# Altium schematic sheet Inverter_Breakout.SchDoc
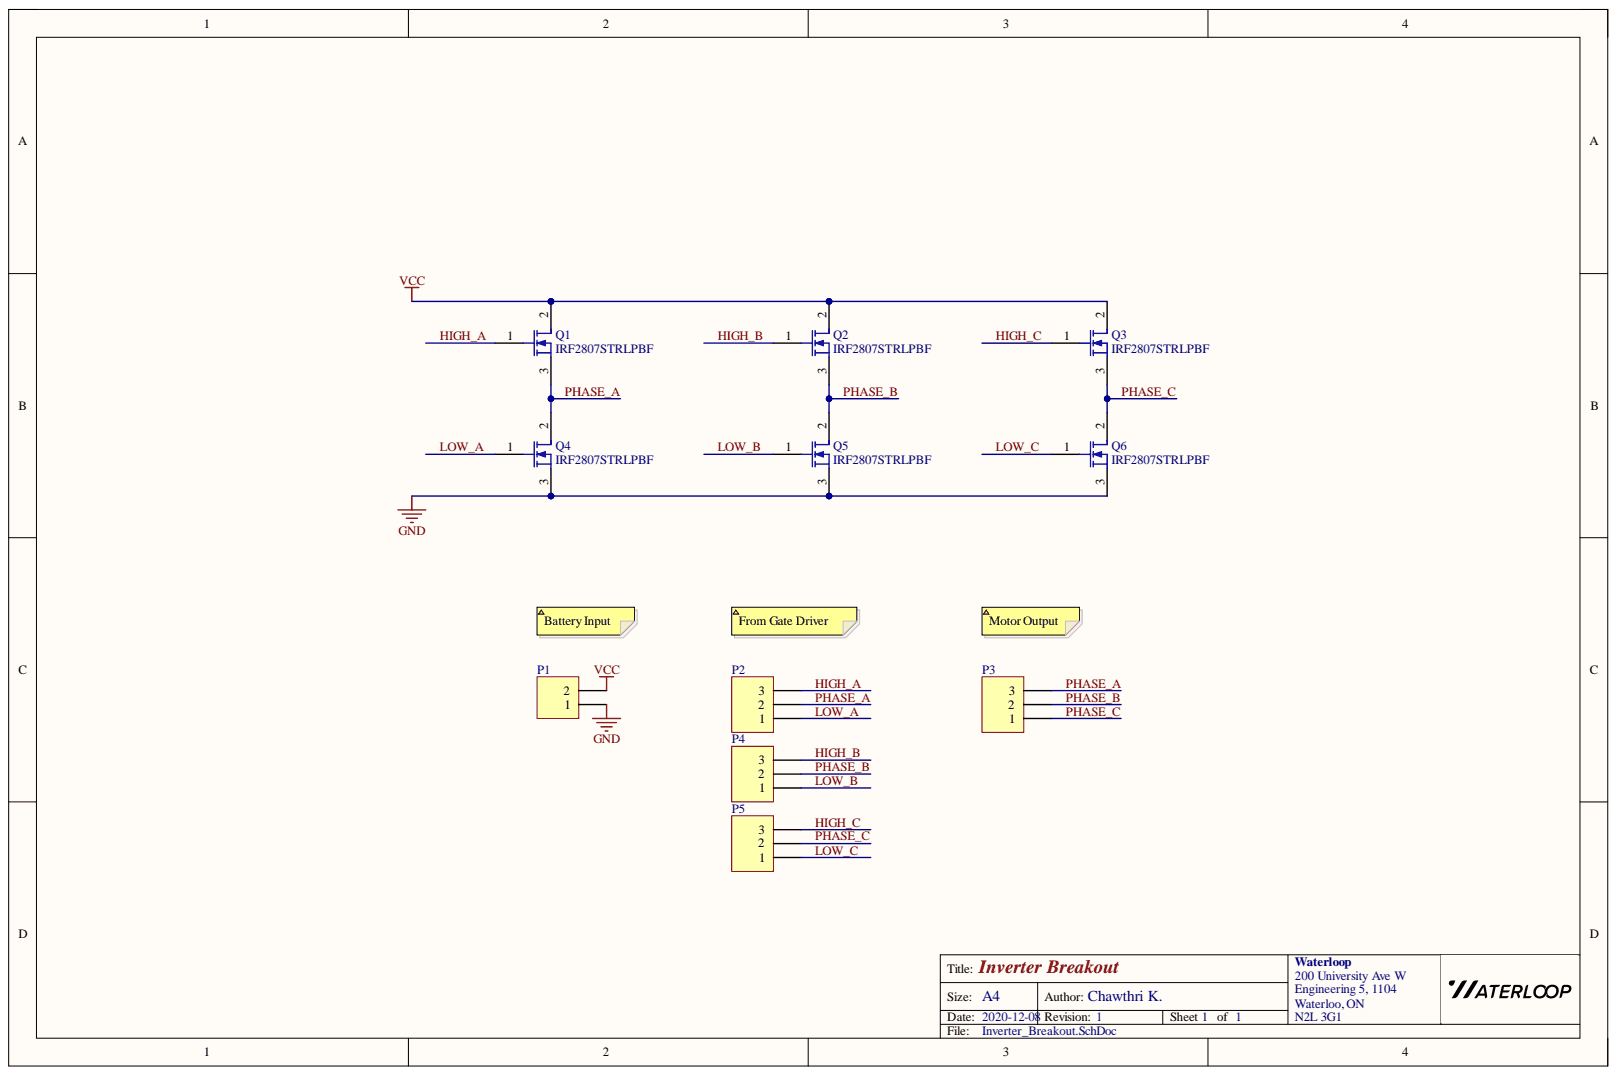
[diagram p0: Inverter_Breakout.SchDoc]

## Parts
P1  1x2 Header  [HDR1X2]  (value not drawn)
P2  1x3 Header  [HDR1X3]  (value not drawn)
P3  1x3 Header  [HDR1X3]  (value not drawn)
P4  1x3 Header  [HDR1X3]  (value not drawn)
P5  1x3 Header  [HDR1X3]  (value not drawn)
Q1  IRF2807STRLPBF  [TO2520AB]
Q2  IRF2807STRLPBF  [TO2520AB]
Q3  IRF2807STRLPBF  [TO2520AB]
Q4  IRF2807STRLPBF  [TO2520AB]
Q5  IRF2807STRLPBF  [TO2520AB]
Q6  IRF2807STRLPBF  [TO2520AB]

## Nets
GND: P1.1 Q4.3 Q5.3 Q6.3
VCC: P1.2 Q1.2 Q2.2 Q3.2
HIGH_A: P2.3 Q1.1
HIGH_B: P4.3 Q2.1
HIGH_C: P5.3 Q3.1
LOW_A: P2.1 Q4.1
LOW_B: P4.1 Q5.1
LOW_C: P5.1 Q6.1
PHASE_A: P2.2 P3.3 Q1.3 Q4.2
PHASE_B: P3.2 P4.2 Q2.3 Q5.2
PHASE_C: P3.1 P5.2 Q3.3 Q6.2

## Library data of the parts
P1 = 1x2 Header: 1x2 Pin Header; Component Kind: Standard; Library Name: GeneralConnectors.IntLib; Signal Integrity: Connector
P2-P5 = 1x3 Header: 1x3 Pin Header; Component Kind: Standard; Library Name: GeneralConnectors.IntLib; Signal Integrity: Connector
Q1-Q6 = MOSFET-N: Manufacturer: Infineon; Rating: 75V 82A; Component Kind: Standard; Description: IRF2807STRLPBF; Library Name: General.SchLib; Manufacturer Part Number: IRF2807STRLPBF; Simulation: NMOS; Supplier: Digikey; Supplier Part Number: IRF2807STRLPBFCT-ND
